# Altium schematic sheet RTC.SchDoc(RTC)
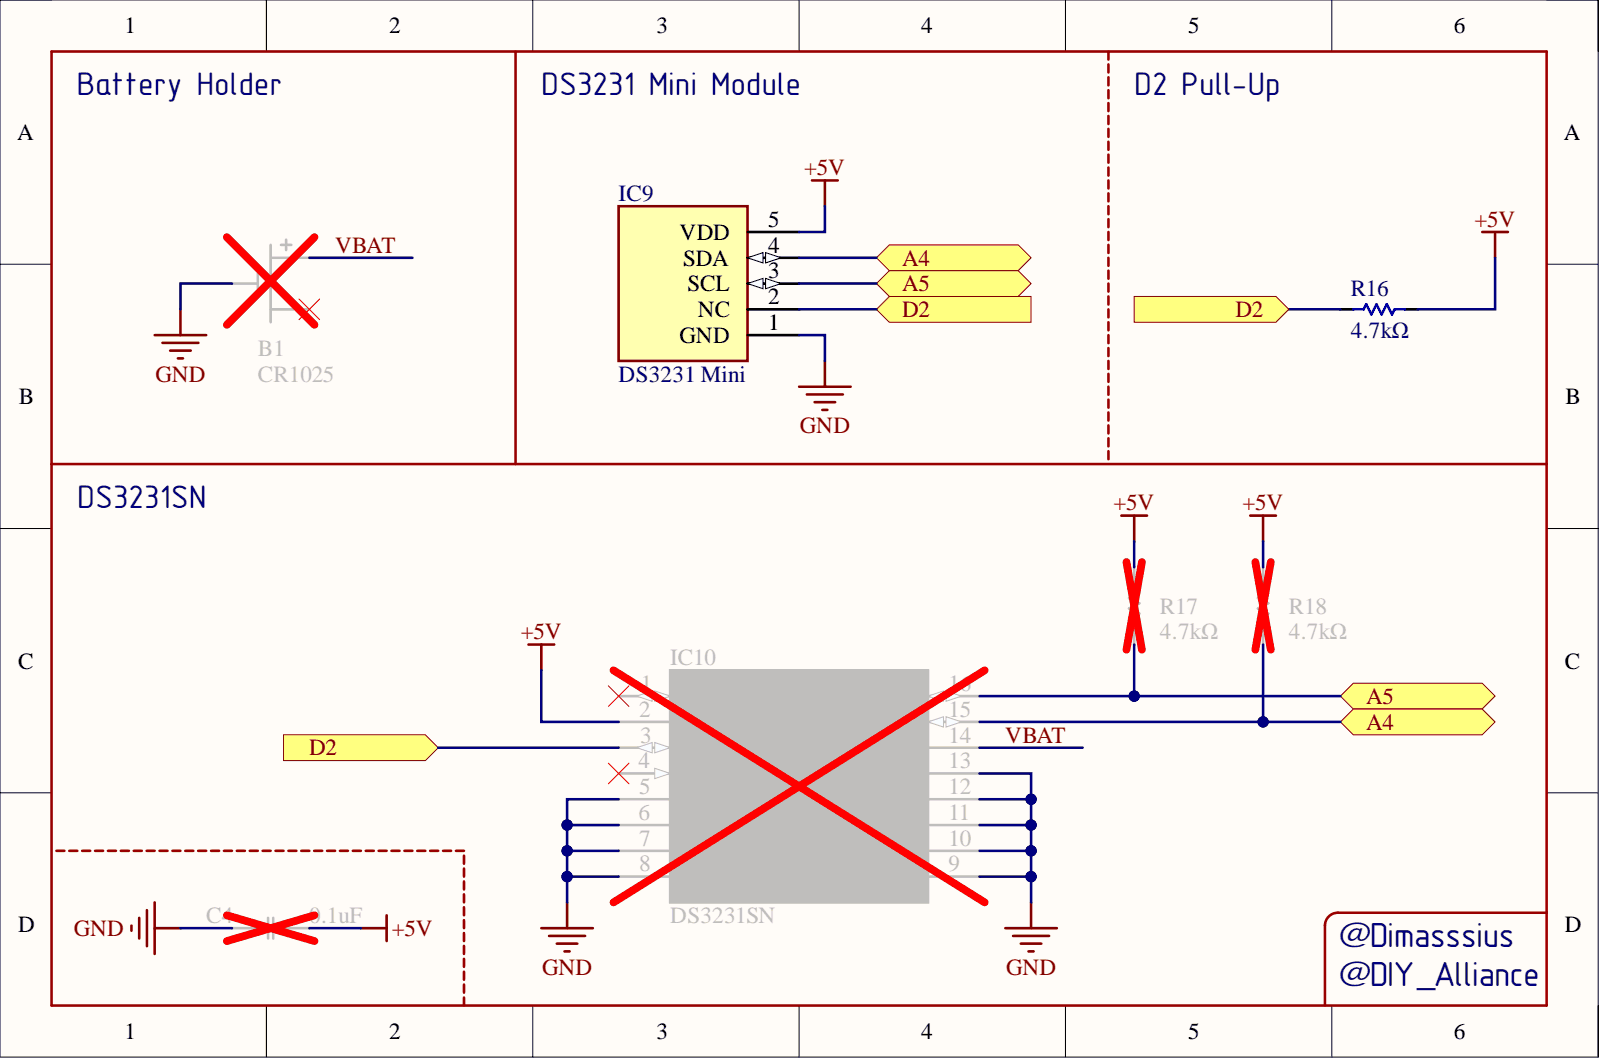
[diagram p0: RTC.SchDoc]

## Parts
B1  CR1025  [CR1025]
C4  0.1uF  [CAP_SMD_MLCC_C0603_(M1608)_C0402A_(M1005A)]
IC9  DS3231 Mini  [DS3231 Mini - Uspide Down]
IC10  DS3231SN  [SOIC127P1032X265-16N]
R16  4.7kΩ  [RES_THD/SMD_R0603_R0402_4.7K_THD]
R16
R16
R17  4.7kΩ  [RES_SMD_R0603_(M1608)_R0402A_(M1005A)]
R18  4.7kΩ  [RES_SMD_R0603_(M1608)_R0402A_(M1005A)]

## Ports
A4, A5, D2

## Nets
+5V: C4.2 IC9.5(VDD) IC10.2(VCC) R16.1 R17.2 R18.1
GND: B1.1 C4.1 IC9.1(GND) IC10.5(N.C._1) IC10.6(N.C._2) IC10.7(N.C._3) IC10.8(N.C._4) IC10.9(N.C._5) IC10.10(N.C._6) IC10.11(N.C._7) IC10.12(N.C._8) IC10.13(GND)
A4: IC9.4(SDA) IC10.15(SDA) R18.2 ; port A4
A5: IC9.3(SCL) IC10.16(SCL) R17.1 ; port A5
D2: IC9.2(NC) IC10.3(INT/SQW) R16.2 ; port D2
VBAT: B1.2 IC10.14(VBAT)

Not connected: B1 3; IC10 1(32KHZ) 4(RST)

## Library data of the parts
B1 = CR1025: Battery Clip Coin, SMD, CR1025, Cell; Component Kind: Standard; Library Name: SamacSys.SchLib; Value: CR1025
C4 = CAP_SMD_MLCC_0.1UF_6.3V: Capacitor, Ceramic (MLCC), SMD, 100nF, 6.3V, ±10%, X7R, Multilayer Ceramic Capacitors MLCC - SMD/SMT, ROHS; Manufacturer: Murata Electronics North America; Part Number: LLM315R71C104MA11L; @ qty: 0; Minimum Quantity: 1; Tolerance: ±20%; Unit Price (USD): 0.9; Voltage: 16V; Applications: Bypass, Decoupling; Component Kind: Standard; Datasheets: http://www.murata.com/~/media/webrenewal/support/library/catalog/products/capacitor/mlcc/c02e.pdf; Digi-Key Part Number: 490-4495-1-ND; Factory Stock: 0; Features: Low ESL (Multi-Terminal); Height - Seated (Max): -; Image: //media.digikey.com/Renders/Murata%20Renders/1206(LLM315).jpg; Lead Spacing: -; Lead Style: -; Library Name: Capacitors.SchLib; Mounting Type: Surface Mount, MLCC; Operating Temperature: -55°C ~ 125°C; Package / Case: -; Packaging: Cut Tape (CT); Part Status: Active; Quantity Available: 2915; Ratings: -; Series: LLM; Size / Dimension: -; Temperature Coefficient: X7R; Thickness (Max): -; Value: 0.1uF
IC9 = DS3231 Mini: Development Board, Real Time Clock; Component Kind: Standard; Library Name: SamacSys.SchLib; Value: DS3231 Mini
IC10 = DS3231SN: Real Time Clock, SOIC-16-300mil, I2C, Real Time Clocks, ROHS; Component Kind: Standard; Datasheet Link: https://datasheet.datasheetarchive.com/originals/distributors/Datasheets-8/DSA-148585.pdf; Height: 2.65mm; Library Name: SamacSys.SchLib; Value: DS3231SN
R16 = RES_THD/SMD_4.7KΩ_R0603_R0402_THD: Resistor, Metal Film Resistor, Through Hole, D2.3xL6.5mm, 4.7kΩ, ±1%, 250mW, Through Hole Resistors, ROHS; Component Kind: Standard; Library Name: Resistors.SchLib; Value: 4.7kΩ
R17 R18 = RES_SMD_4.7KΩ: Resistor, Thick Film Resistor, SMD, 4.7kΩ, ±5%, Chip Resistor - Surface Mount, ROHS; Component Kind: Standard; Library Name: Resistors.SchLib; Value: 4.7kΩ
